# Altium schematic sheet Connections.SchDoc
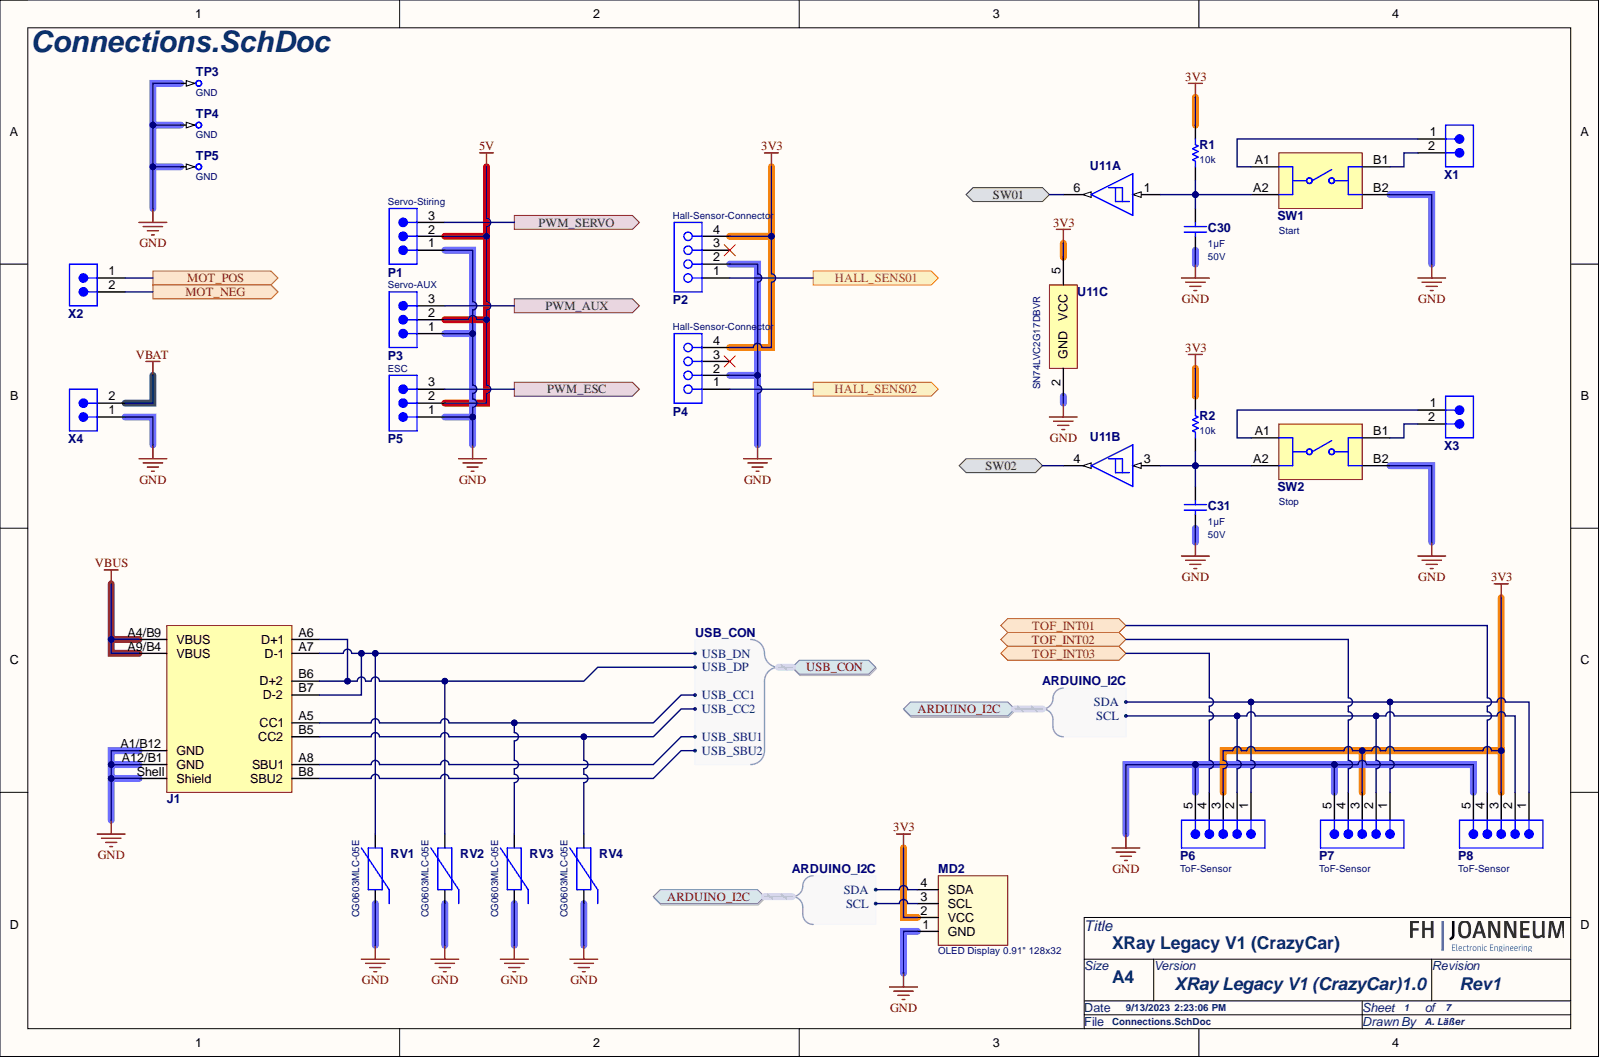
[diagram p0: Connections.SchDoc]

## Parts
C30  1µF  Rated Voltage (DC): 50V  [WCAP-CSGP_0805_L2W1.25H1.25]
C31  1µF  Rated Voltage (DC): 50V  [WCAP-CSGP_0805_L2W1.25H1.25]
J1  629722000214  [629722000214]  (value not drawn)
MD2  OLED Display 0.91" 128x32  [OLED Display 0.91]
P1  Servo-Stiring  [61300311021]
P2  Hall-Sensor-Connector  [61300415721]
P3  Servo-AUX  [61300311021]
P4  Hall-Sensor-Connector  [61300415721]
P5  ESC  [61300311021]
P6  ToF-Sensor  [61300511121]
P7  ToF-Sensor  [61300511121]
P8  ToF-Sensor  [61300511121]
R1  Resistance: 10k  [RESC2013X60X35ML10T20]  (hidden comment: RC0805FR-0710KL)
R2  Resistance: 10k  [RESC2013X60X35ML10T20]  (hidden comment: RC0805FR-0710KL)
RV1  CG0603MLC-05E  [BOUR-CG0603-2_V]
RV2  CG0603MLC-05E  [BOUR-CG0603-2_V]
RV3  CG0603MLC-05E  [BOUR-CG0603-2_V]
RV4  CG0603MLC-05E  [BOUR-CG0603-2_V]
SW1  Start  [WS-TASV_L4.7W3.5H2.5_4341x30258x6]
SW2  Stop  [WS-TASV_L4.7W3.5H2.5_4341x30258x6]
TP3  GND  [KEYSTONE-5016_V]
TP4  GND  [KEYSTONE-5016_V]
TP5  GND  [KEYSTONE-5016_V]
U11  SN74LVC2G17DBVR  [DBV0006A_M]  (parts A,B,C)
X1  61300211121  [61300211121]  (value not drawn)
X2  66200211022  [66200211022]  (value not drawn)
X3  61300211121  [61300211121]  (value not drawn)
X4  649002127222  [649002127222]  (value not drawn)

## Ports
ARDUINO_I2C, ARDUINO_I2C.SCL, ARDUINO_I2C.SDA, HALL_SENS01, HALL_SENS02, MOT_NEG, MOT_POS, PWM_AUX, PWM_ESC, PWM_SERVO, SW01, SW02, TOF_INT01, TOF_INT02, TOF_INT03, USB_CON, USB_CON.USB_CC1, USB_CON.USB_CC2, USB_CON.USB_DN, USB_CON.USB_DP, USB_CON.USB_SBU1, USB_CON.USB_SBU2

## Nets
3V3: MD2.2(VCC) P2.4 P4.4 P6.3 P7.3 P8.3 R1.1 R2.1 U11.5(VCC)
5V: P1.2 P3.2 P5.2
GND: C30.2 C31.2 J1.A1/B12(GND) J1.A12/B1(GND) J1.Shell(Shield) MD2.1(GND) P1.1 P2.2 P3.1 P4.2 P5.1 P6.5 P7.5 P8.5 RV1.1 RV2.1 RV3.1 RV4.1 SW1.B2 SW2.B2 TP3.1 TP4.1 TP5.1 U11.2(GND) X4.1
VBAT: X4.2
VBUS: J1.A4/B9(VBUS) J1.A9/B4(VBUS)
HALL_SENS01: P2.1 ; port HALL_SENS01
HALL_SENS02: P4.1 ; port HALL_SENS02
MOT_NEG: X2.2 ; port MOT_NEG
MOT_POS: X2.1 ; port MOT_POS
PWM_AUX: P3.3 ; port PWM_AUX
PWM_ESC: P5.3 ; port PWM_ESC
PWM_SERVO: P1.3 ; port PWM_SERVO
SW01: U11.6 ; port SW01
SW02: U11.4 ; port SW02
TOF_INT01: P8.4 ; port TOF_INT01
TOF_INT02: P7.4 ; port TOF_INT02
TOF_INT03: P6.4 ; port TOF_INT03
NetC30_1: C30.1 R1.2 SW1.A2 U11.1
NetC31_1: C31.1 R2.2 SW2.A2 U11.3
NetJ1_A5: J1.A5(CC1) RV3.2 ; port USB_CON
NetJ1_A6: J1.A6(D+1) J1.B6(D+2) RV2.2 ; port USB_CON
NetJ1_A7: J1.A7(D-1) J1.B7(D-2) RV1.2 ; port USB_CON
NetJ1_A8: J1.A8(SBU1) ; port USB_CON
NetJ1_B5: J1.B5(CC2) RV4.2 ; port USB_CON
NetJ1_B8: J1.B8(SBU2) ; port USB_CON
NetMD2_3: MD2.3(SCL) P6.2 P7.2 P8.2 ; port ARDUINO_I2C
NetMD2_4: MD2.4(SDA) P6.1 P7.1 P8.1 ; port ARDUINO_I2C
NetSW1_A1: SW1.A1 X1.1
NetSW1_B1: SW1.B1 X1.2
NetSW2_A1: SW2.A1 X3.1
NetSW2_B1: SW2.B1 X3.2

Not connected: P2 3; P4 3

## Library data of the parts
C30 C31 = 885012207103: WCAP-CSGP Multilayer Ceramic Chip Capacitor, General Purpose, size 0805, X7R, 1µF, 50VDC; Manufacturer: Wurth Elektronik; Capacitance Tolerance: +/-10%; Case/Size Code: 0805; Dissipation Factor: 10%; Insulation Resistance: 0.1GΩ; Technical Reference: X7R0805105K050DFCT10000; Capacitance: 1µF; Category: Multilayer Ceramic Chip Capacitors; Ceramic Type: X7R; Component Kind: Standard; ComponentLink1Description: Website Link; ComponentLink1URL: https://www.we-online.com/redexpert/article/885012207103?ad; ComponentLink2Description: Datasheet Link; ComponentLink2URL: https://www.we-online.com/redexpert/spec/885012207103?ad; Height: 1.25mm; Length: 2mm; Library Name: Altium_WCAP-CSGP (rev22e).IntLib; Manufacturer Part Number: 885012207103; Match Code: WCAP-CSGP; Mount: Surface Mount; Operating Temperature Max: 125°C; Operating Temperature Min: -55°C; Packaging: Tape and Reel; Width: 1.25mm
J1 = 629722000214: WR-USB 2.0 Type C, Receptacle, Horizontal, SMT; Manufacturer: Wurth Elektronik; Interface Type: Type C; Number of Ports: 1; Rated Current: 5A; Working Voltage: 20VAC; Application: USB 2.0; Category: Input/Output Connectors; Component Kind: Standard; ComponentLink1Description: Website Link; ComponentLink1URL: https://www.we-online.com/redexpert/article/629722000214?ad; ComponentLink2Description: Datasheet Link; ComponentLink2URL: https://www.we-online.com/redexpert/spec/629722000214?ad; Gender: Receptacle; Library Name: Altium_WR-USB (rev22b).IntLib; Manufacturer Part Number: 629722000214; Match Code: WR-USB; Mount: Surface Mount; Number of Pins: 16; Operating Temperature Max: 85°C; Operating Temperature Min: -40°C; Orientation: Horizontal; Packaging: Tape and Reel
MD2 = OLED Display 0.91'': Component Kind: Standard; Library Name: Schlib1.SchLib
P1 P3 P5 = 61300311021: WR-PHD Pin Header, THT, Angled, pitch 2.54mm, 1 Row, 3P; Manufacturer: Wurth Elektronik; Contact Resistance: 20mΩ; Rated Current: 3A; Withstanding Voltage: 500V; Working Voltage: 250V; Category: Board-to-Board Connectors; Component Kind: Standard; ComponentLink1Description: Website Link; ComponentLink1URL: https://www.we-online.com/redexpert/article/61300311021?ad; ComponentLink2Description: Datasheet Link; ComponentLink2URL: https://www.we-online.com/redexpert/spec/61300311021?ad; Gender: Pin Header; Library Name: Altium_WR-PHD (rev22b).IntLib; Manufacturer Part Number: 61300311021; Match Code: WR-PHD; Mount: Through Hole; Number of Pins: 3; Number of Rows: 1; Operating Temperature Max: 105°C; Operating Temperature Min: -40°C; Orientation: Angled; Packaging: Bag; Pitch: 2.54mm
P2 P4 = 61300415721: WR-PHD Socket Header, THT, Vertical, pitch 2.54mm, 1 Row, 4P; Manufacturer: Wurth Elektronik; Contact Resistance: 20mΩ; Rated Current: 3A; Withstanding Voltage: 500V; Working Voltage: 250V; Category: Board-to-Board Connectors; Component Kind: Standard; ComponentLink1Description: Website Link; ComponentLink1URL: https://www.we-online.com/redexpert/article/61300415721?ad; ComponentLink2Description: Datasheet Link; ComponentLink2URL: https://www.we-online.com/redexpert/spec/61300415721?ad; Gender: Socket Header; Library Name: Altium_WR-PHD (rev22b).IntLib; Manufacturer Part Number: 61300415721; Match Code: WR-PHD; Mount: Through Hole; Number of Pins: 4; Number of Rows: 1; Operating Temperature Max: 125°C; Operating Temperature Min: -40°C; Orientation: Vertical; Packaging: Tube; Pitch: 2.54mm
P6-P8 = 61300511121: WR-PHD Pin Header, THT, Vertical, pitch 2.54mm, 1 Row, 5P; Manufacturer: Wurth Elektronik; Contact Resistance: 20mΩ; Rated Current: 3A; Withstanding Voltage: 500V; Working Voltage: 250V; Category: Board-to-Board Connectors; Component Kind: Standard; ComponentLink1Description: Website Link; ComponentLink1URL: https://www.we-online.com/redexpert/article/61300511121?ad; ComponentLink2Description: Datasheet Link; ComponentLink2URL: https://www.we-online.com/redexpert/spec/61300511121?ad; Gender: Pin Header; Library Name: Altium_WR-PHD (rev22b).IntLib; Manufacturer Part Number: 61300511121; Match Code: WR-PHD; Mount: Through Hole; Number of Pins: 5; Number of Rows: 1; Operating Temperature Max: 105°C; Operating Temperature Min: -40°C; Orientation: Vertical; Packaging: Bag; Pitch: 2.54mm
R1 R2 = CMP-1659-00013-4: Chip Resistor, 10 KOhm, +/- 1%, 0.125 W, -55 to 155 degC, 0805 (2012 Metric), RoHS, Tape and Reel; Manufacturer: Yageo; Additional Feature: LASER TRIMMABLE; Package Height: 0.5mm; Package Length: 2mm; Package Width: 1.25mm; Rated Power Dissipation (P): 125mW; Rated Temperature: 70°C; Temperature Coefficient: 100ppm/°C; Voltage Rating: 150 V; Working Voltage: 150V; Category: Chip SMD Resistors; Component Kind: Standard; ComponentLink1Description: Manufacturer URL; ComponentLink1URL: http://www.yageo.com/; ComponentLink2Description: Datasheet; ComponentLink2URL: http://www.yageo.com/documents/recent/PYu-RC_Group_51_RoHS_L_04.pdf; ComponentLink3Description: https://datasheet.ciiva.com:443/pdfs/VipMasterIC/IC/YAGO/YAGO-S-A0008145938/YAGO-S-A0008145938-1.pdf?src-supplier=IHS+Markit; ComponentLink3URL: https://datasheet.ciiva.com:443/pdfs/VipMasterIC/IC/YAGO/YAGO-S-A0008145938/YAGO-S-A0008145938-1.pdf?src-supplier=IHS+Markit; ComponentLink4Description: https://datasheet.ciiva.com/11808/0900766b8106beab-11808151.pdf?src-supplier=RSComponents; ComponentLink4URL: https://datasheet.ciiva.com/11808/0900766b8106beab-11808151.pdf?src-supplier=RSComponents; ComponentLink5Description: https://datasheet.ciiva.com/46447/444164-46447563.pdf?src-supplier=Element14; ComponentLink5URL: https://datasheet.ciiva.com/46447/444164-46447563.pdf?src-supplier=Element14; ComponentLink6Description: https://datasheet.ciiva.com/2456/pyu-r-int-thick-7-2456973.pdf?src-supplier=Digi-Key; ComponentLink6URL: https://datasheet.ciiva.com/2456/pyu-r-int-thick-7-2456973.pdf?src-supplier=Digi-Key; ComponentLink7Description: https://datasheet.ciiva.com/2456/pyu-r-int-thick-7-2456974.pdf?src-supplier=Digi-Key; ComponentLink7URL: https://datasheet.ciiva.com/2456/pyu-r-int-thick-7-2456974.pdf?src-supplier=Digi-Key; ComponentLink8Description: https://datasheet.ciiva.com/2456/pyu-r-int-thick-7-2456972.pdf?src-supplier=Digi-Key; ComponentLink8URL: https://datasheet.ciiva.com/2456/pyu-r-int-thick-7-2456972.pdf?src-supplier=Digi-Key; ComponentLink9Description: https://datasheet.ciiva.com/12669/rc0805-12669688.pdf?src-supplier=Mouser; ComponentLink9URL: https://datasheet.ciiva.com/12669/rc0805-12669688.pdf?src-supplier=Mouser; ComponentLink10Description: https://datasheet.ciiva.com/16747/rc0805-16747087.pdf?src-supplier=Future+Electronics; ComponentLink10URL: https://datasheet.ciiva.com/16747/rc0805-16747087.pdf?src-supplier=Future+Electronics; Construction: Chip; DatasheetVersion: Rev. 4, 02/2016; Height: 0.5 mm; JESD-609 Code: e3; Length: 2 mm; Library Name: Altium Content Vault; Manufacturer Part Number: RC0805FR-0710KL; Max Operating Temperature: 155 degC; Min Operating Temperature: -55 degC; Mount: Surface Mount; Mounting Technology: Surface Mount; Number of Pins: 2; Package Quantity: 5000; Package Shape: Rectangular; Package Style: SMTMeter; PackageDescription: 2-Pin Surface Mounted Device, Body 2 x 1.25 mm; PackageReference: 0805; Packaging: Tape and Reel; Pins: 2; Pricing 1: 5000=0.00700 (USD); REACH SVHC: No SVHC; Resistor Type: FIXED RESISTOR; RoHS: Yes; RoHS Compliant: Yes; RoHSCompliant: true; Size Code: 0805; Stock 1: 435000; Supplier 1: RSComponents; Supplier Part Number 1: 6185163; Technology: METAL GLAZE/THICK FILM; Terminal Finish: Nickel; Termination: Wraparound; Tolerance: 1%
RV1-RV4 = CMP-0584-00001-2: ESD Protector, 5 V Supply, -40 to 85 degC, 1.6 x 0.8 x 0.55 mm SMD, RoHS, Tape and Reel; Manufacturer: Bourns; Circuit DC Voltage-Max: 5V; Circuit RMS Voltage-Max: 20V; CO: 0.5 pF; Reference Standard: AEC-Q200; RT: 1 ns; VC: 20 V; VDC: 5 V; VT: 150 V; Category: Varistors; Component Kind: Standard; ComponentLink1Description: Manufacturer URL; ComponentLink1URL: http://www.bourns.com/; ComponentLink2Description: Datasheet; ComponentLink2URL: http://www.bourns.com/data/global/pdfs/MLC.pdf; ComponentLink3Description: https://datasheet.ciiva.com:443/pdfs/VipMasterIC/IC/BOUR/BOUR-S-A0008954794/BOUR-S-A0008954794-1.pdf?src-supplier=IHS+Markit; ComponentLink3URL: https://datasheet.ciiva.com:443/pdfs/VipMasterIC/IC/BOUR/BOUR-S-A0008954794/BOUR-S-A0008954794-1.pdf?src-supplier=IHS+Markit; ComponentLink4Description: https://datasheet.ciiva.com/27747/1163231-27747629.pdf?src-supplier=Jameco; ComponentLink4URL: https://datasheet.ciiva.com/27747/1163231-27747629.pdf?src-supplier=Jameco; ComponentLink5Description: https://datasheet.ciiva.com/30070/mlc-30070503.pdf?src-supplier=Onlinecomponents.com; ComponentLink5URL: https://datasheet.ciiva.com/30070/mlc-30070503.pdf?src-supplier=Onlinecomponents.com; ComponentLink6Description: https://datasheet.ciiva.com/6991/mlc-6991832.pdf?src-supplier=Digi-Key; ComponentLink6URL: https://datasheet.ciiva.com/6991/mlc-6991832.pdf?src-supplier=Digi-Key; ComponentLink7Description: https://datasheet.ciiva.com/6991/mlc-6991834.pdf?src-supplier=Digi-Key; ComponentLink7URL: https://datasheet.ciiva.com/6991/mlc-6991834.pdf?src-supplier=Digi-Key; ComponentLink8Description: https://datasheet.ciiva.com/1278/1785244-1278701.pdf?src-supplier=Element14; ComponentLink8URL: https://datasheet.ciiva.com/1278/1785244-1278701.pdf?src-supplier=Element14; ComponentLink9Description: https://datasheet.ciiva.com/25958/mlc-25958222.pdf?src-supplier=Avnet+Europe; ComponentLink9URL: https://datasheet.ciiva.com/25958/mlc-25958222.pdf?src-supplier=Avnet+Europe; ComponentLink10Description: https://datasheet.ciiva.com/8084/mlc-8084047.pdf?src-supplier=Avnet; ComponentLink10URL: https://datasheet.ciiva.com/8084/mlc-8084047.pdf?src-supplier=Avnet; ComponentLink11Description: https://datasheet.ciiva.com/6991/mlc-6991836.pdf?src-supplier=Digi-Key; ComponentLink11URL: https://datasheet.ciiva.com/6991/mlc-6991836.pdf?src-supplier=Digi-Key; ComponentLink12Description: https://datasheet.ciiva.com/22696/1785244-22696318.pdf?src-supplier=Element14; ComponentLink12URL: https://datasheet.ciiva.com/22696/1785244-22696318.pdf?src-supplier=Element14; DatasheetVersion: Rev. S; JESD-609 Code: e3; Library Name: Altium Content Vault; Manufacturer Part Number: CG0603MLC-05E; Max Operating Temperature: 85°C; Min Operating Temperature: -40°C; Mount: Surface Mount; Mounting Technology: Surface Mount; Number of Pins: 2; Operating Temperature: -40 to 85 degC; Package Shape: Rectangular; PackageDescription: 2-Pin SMD, Body 1.6 x 0.8 mm, Pitch 1.52 mm; PackageReference: CG0603-2; Packaging: Tape and Reel; Packing: Tape and Reel; PartNumber: CG0603MLC-05E; Pricing 1: 5000=0.09000 (USD); REACH SVHC: Yes; Resistor Type: VARISTOR; RoHS: TRUE; RoHS Compliant: Yes; Stock 1: 6000; Supplier 1: RSComponents; Supplier Part Number 1: 1814389; Terminal Finish: Tin; Terminal Placement: Dual Ended; Termination: Wraparound
SW1 SW2 = 434133025826: WS-TASV J-Bend SMT Tact Switch, L4.7 x W3.5 x H2.5mm, 260g, SPST-NO, 15V, 20mA; Manufacturer: Wurth Elektronik; Washable: No; Category: Tact Switches; Circuit: SPST-NO; Component Kind: Standard; ComponentLink1Description: Website Link; ComponentLink1URL: https://www.we-online.com/redexpert/article/434133025826?ad; ComponentLink2Description: Datasheet Link; ComponentLink2URL: https://www.we-online.com/redexpert/spec/434133025826?ad; Contact Material: Stainless Steel; Contact Plating: Silver; Height: 2.5mm; Length: 4.7mm; Library Name: Altium_WS-TASV (rev22c).IntLib; Manufacturer Part Number: 434133025826; Match Code: WS-TASV; Mount: Surface Mount; Operating Temperature Max: 85°C; Operating Temperature Min: -40°C; Operation Force: 260g; Packaging: Tape and Reel; Rated Current: 20mA; Rated Voltage (DC): 15V; Terminal Material: Copper Alloy; Terminal Plating: Silver; Width: 3.5mm
TP3-TP5 = CMP-2000-05178-1: Test Point, 1-Pin SMT, RoHS, Tape and Reel; Manufacturer: Keystone; Catalog Drawings: 5016Top    5016Side    5016Front; Featured Product: SurfaceMountTestPoints    5019MiniatureSurfaceMountTestPoint; Online Catalog: SurfaceMountTestPoints; Other Names: 5016KDKR; Category: TestandMeasurement; Component Kind: Standard; Datasheet URL: https://ciiva.com/datasheet-click-2917613?src=ciiva&sig=4a4e90f; Datasheet Version: Rev. D, 03/2014; Family: TestPoints; Library Name: Altium Content Vault; Manufacturer URL: http://www.keyelco.com/; Material: PhosphorBronze; Mounting Type: SurfaceMount; Package Description: 1-Pin Test Point, Body 3.81 x 2.04 mm; Package Reference: 5016; Packaging: Digi-Reel; RoHS Information: RoHSComplianceStatement; Standard Package: 1; Terminal: 0.015"(0.38mm)PhosphorBronze,SilverPlated; Type: PCTestPoint,Compact
U11 = CMP-0859-00225-4: Dual Schmitt-Trigger Buffer, DBV0006A, LARGE T&R; Manufacturer: Texas Instruments; 3-State Output: No; Bits(#): 2; F @ Nom Voltage(Max)(Mhz): 150; ICC @ Nom Voltage(Max)(mA): 0.01; Input Type: CMOS/TTL; Logic: True; Output Drive (IOL/IOH)(Max)(mA): 32/-32; Output Type: CMOS; Rating: Catalog; Schmitt Trigger: Yes; Sub-Family: Non-Inverting Buffer/Driver; Technology Family: LVC; tpd @ Nom Voltage(Max)(ns): 9.3,5.7,5.4,4.3; VCC(Max)(V): 5.5; VCC(Min)(V): 1.65; Voltage(Nom)(V): 1.8,2.5,3.3,5; Component Kind: Standard; DatasheetVersion: SCES381N; Generic Part Number: SN74LVC2G17; Library Name: Altium Content Vault; Operating Temperature Range(C): -40 to 125,-40 to 85; PackageReference: DBV0006A
X1 X3 = 61300211121: WR-PHD Pin Header, THT, Vertical, pitch 2.54mm, 1 Row, 2P; Manufacturer: Wurth Elektronik; Contact Resistance: 20mΩ; Rated Current: 3A; Withstanding Voltage: 500V; Working Voltage: 250V; Category: Board-to-Board Connectors; Component Kind: Standard; ComponentLink1Description: Website Link; ComponentLink1URL: https://www.we-online.com/redexpert/article/61300211121?ad; ComponentLink2Description: Datasheet Link; ComponentLink2URL: https://www.we-online.com/redexpert/spec/61300211121?ad; Gender: Pin Header; Library Name: Altium_WR-PHD (rev22b).IntLib; Manufacturer Part Number: 61300211121; Match Code: WR-PHD; Mount: Through Hole; Number of Pins: 2; Number of Rows: 1; Operating Temperature Max: 105°C; Operating Temperature Min: -40°C; Orientation: Vertical; Packaging: Bag; Pitch: 2.54mm
X2 = 66200211022: WR-MPC3 THT Male Right Angled Header with Plastic Pegs, pitch 3mm, 2p; Manufacturer: Wurth Elektronik; Contact Resistance: 10mΩ; Insulation Resistance: >1000MΩ; Rated Current: 5A; Working Voltage: 250VAC; Category: Wire-to-Board Connectors; Component Kind: Standard; ComponentLink1Description: Website Link; ComponentLink1URL: https://www.we-online.com/redexpert/article/66200211022?ad; ComponentLink2Description: Datasheet Link; ComponentLink2URL: https://www.we-online.com/redexpert/spec/66200211022?ad; Gender: Male; Height: 5.57mm; Length: 9.65mm; Library Name: Altium_WR-MPC3 (rev22a).IntLib; Manufacturer Part Number: 66200211022; Match Code: WR-MPC3; Mount: Through Hole; Number of Pins: 2; Number of Rows: 1; Operating Temperature Max: 105°C; Operating Temperature Min: -40°C; Orientation: Right Angled; Packaging: Tube; Pitch: 3mm; Width: 9.9mm
X4 = 649002127222: WR-MPC4 THT Male Right Angled Header with Mounting Pegs, pitch 4.2mm, 2p; Manufacturer: Wurth Elektronik; Contact Resistance: 10mΩ; Insulation Resistance: 1000MΩ; Rated Current: 9A; Working Voltage: 600VAC; Category: Wire-to-Board Connectors; Component Kind: Standard; ComponentLink1Description: Website Link; ComponentLink1URL: https://www.we-online.com/redexpert/article/649002127222?ad; ComponentLink2Description: Datasheet Link; ComponentLink2URL: https://www.we-online.com/redexpert/spec/649002127222?ad; Gender: Male; Library Name: Altium_WR-MPC4 (rev22a).IntLib; Manufacturer Part Number: 649002127222; Match Code: WR-MPC4; Mount: Through Hole; Number of Pins: 2; Number of Rows: 1; Operating Temperature Max: 105°C; Operating Temperature Min: -40°C; Orientation: Right Angled; Packaging: Tray; Pitch: 4.2mm
